# Altium schematic sheet 07_CAMERA_INTERFACE_2.SchDoc(07_CAMERA_INTERFACE_2)
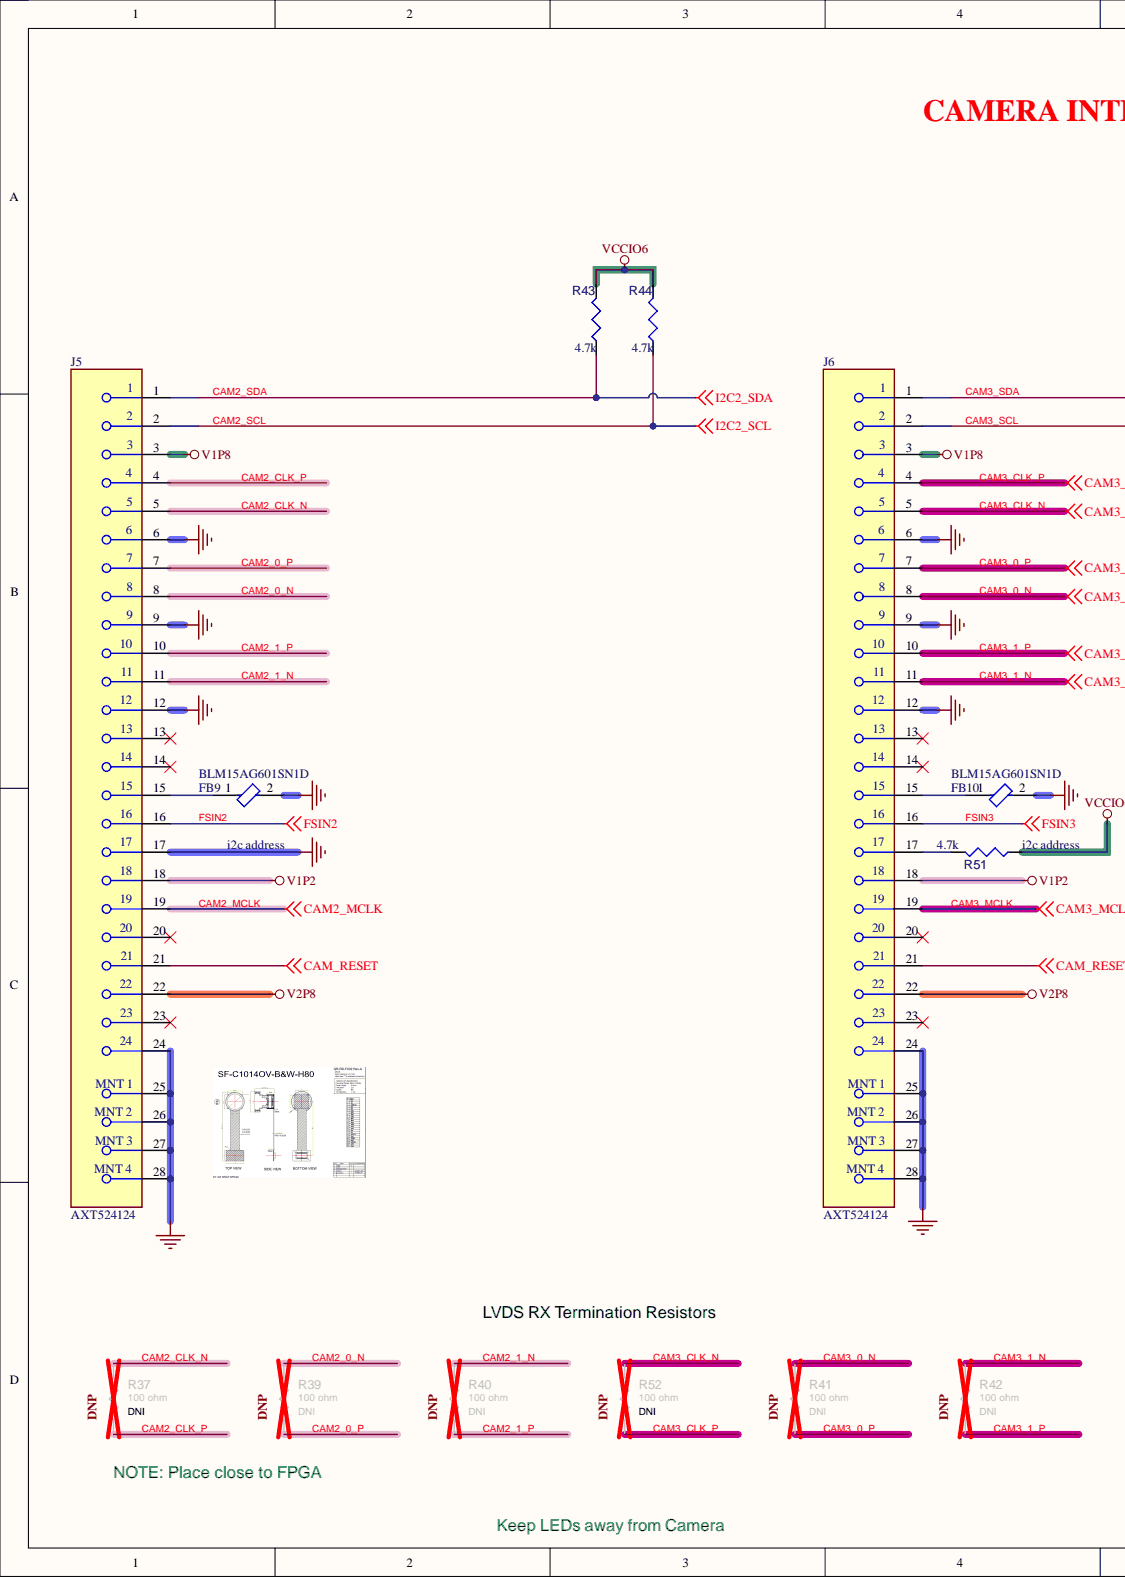
[diagram p0: 07_CAMERA_INTERFACE_2.SchDoc, left]
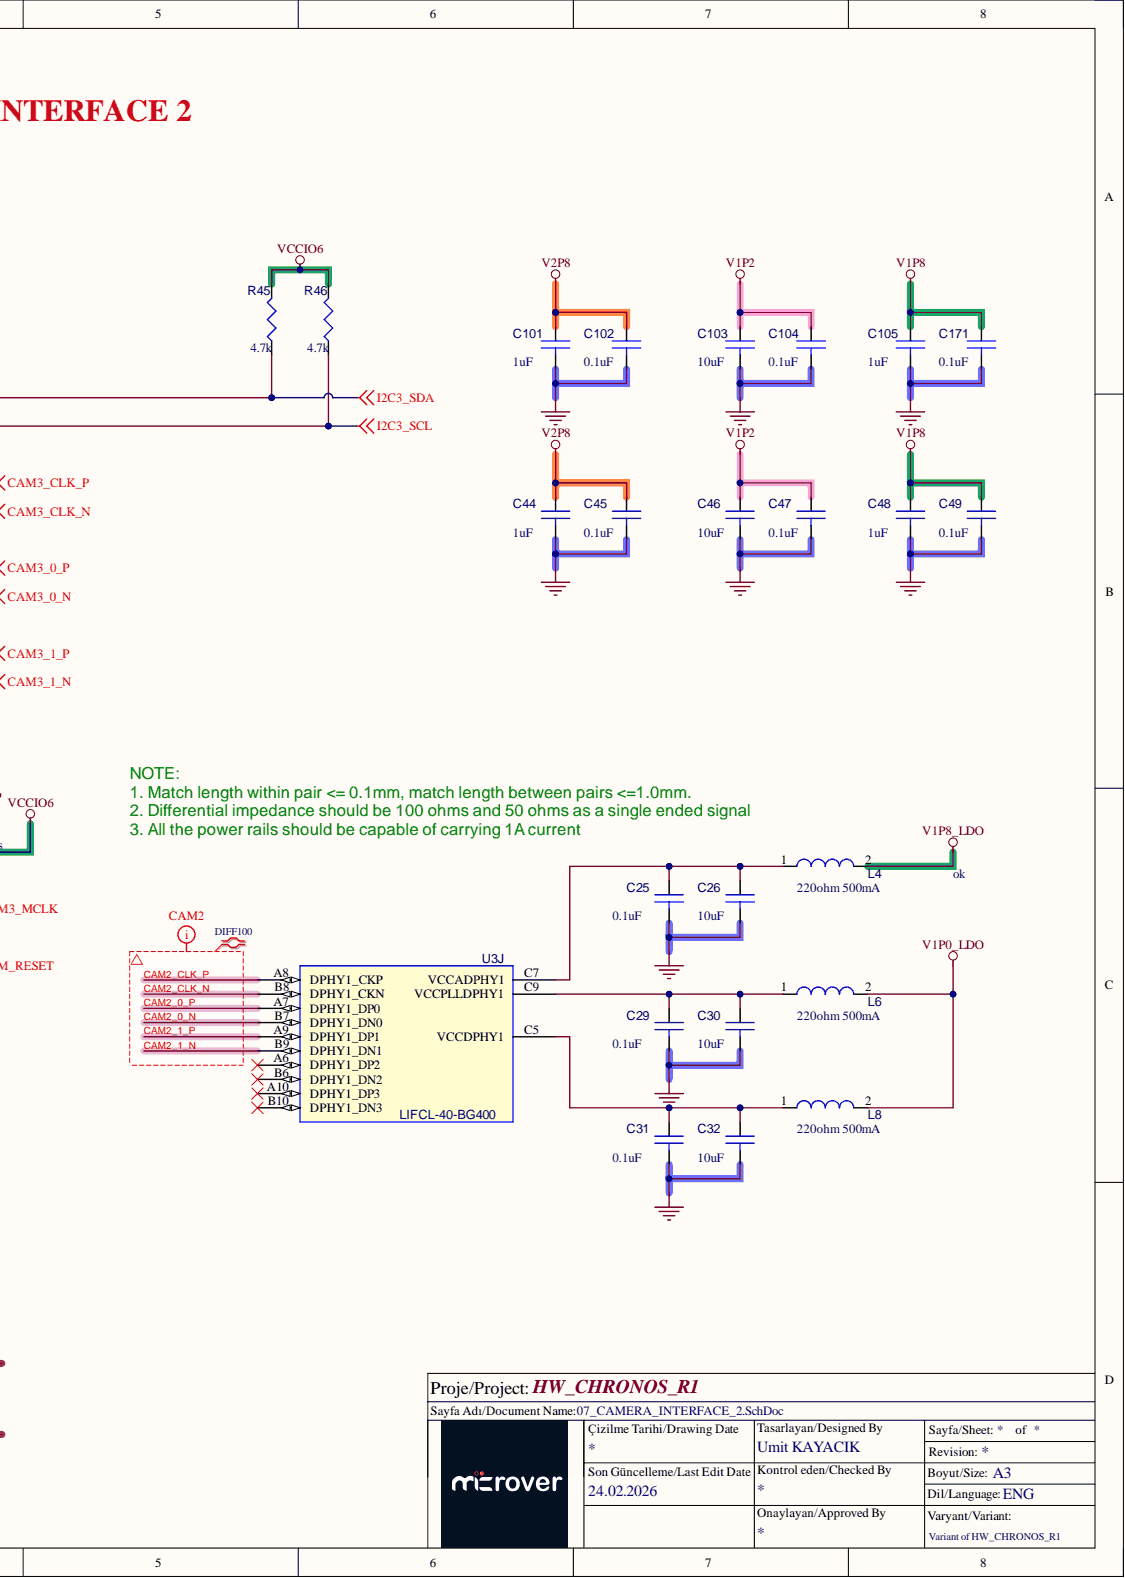
[diagram p1: 07_CAMERA_INTERFACE_2.SchDoc, right]

## Parts
C25  0.1uF  Part Reference: C25  [C0201]
C26  10uF  Part Reference: C26  [C0402]
C29  0.1uF  Part Reference: C29  [C0201]
C30  10uF  Part Reference: C30  [C0402]
C31  0.1uF  Part Reference: C31  [C0201]
C32  10uF  Part Reference: C32  [C0402]
C44  1uF  [C0603]
C45  0.1uF  [C0201]
C46  10uF  [C0603]
C47  0.1uF  [C0201]
C48  1uF  [C0603]
C49  0.1uF  [C0201]
C101  1uF  [C0603]
C102  0.1uF  [C0201]
C103  10uF  [C0603]
C104  0.1uF  [C0201]
C105  1uF  [C0603]
C171  0.1uF  [C0201]
FB9  BLM15AG601SN1D  [FP-BLM15-0_05-MFG]
FB10  BLM15AG601SN1D  [FP-BLM15-0_05-MFG]
J5  AXT524124  [FP-AXT524124-MFG]
J6  AXT524124  [FP-AXT524124-MFG]
L4  220ohm 500mA  Part Reference: L4  [FB0402]
L6  220ohm 500mA  Part Reference: L6  [FB0402]
L8  220ohm 500mA  Part Reference: L8  [FB0402]
R37  100 ohm  Assy: DNI  [R0201]
R39  100 ohm  Assy: DNI  [R0201]
R40  100 ohm  Assy: DNI  [R0201]
R41  100 ohm  Assy: DNI  [R0201]
R42  100 ohm  Assy: DNI  [R0201]
R43  4.7k  [R0402]
R44  4.7k  [R0402]
R45  4.7k  [R0402]
R46  4.7k  [R0402]
R51  4.7k  [R0402]
R52  100 ohm  Assy: DNI  [R0201]
U3  LIFCL-40-BG400  [LIFCL-40-BG400]  (part J)

## Nets
CAM_RESET: J5.21 J6.21
GND: C25.2 C26.2 C29.2 C30.2 C31.2 C32.2 C44.2 C45.2 C46.2 C47.2 C48.2 C49.2 C101.2 C102.2 C103.2 C104.2 C105.2 C171.2 FB9.2 FB10.2 J5.6 J5.9 J5.12 J5.17 J5.24 J5.25 J5.26 J5.27 J5.28 J6.6 J6.9 J6.12 J6.24 J6.25 J6.26 J6.27 J6.28
V1P0_LDO: L6.2 L8.2
V1P2: C46.1 C47.1 C103.1 C104.1 J5.18 J6.18
V1P8: C48.1 C49.1 C105.1 C171.1 J5.3 J6.3
V1P8_LDO: L4.2
V2P8: C44.1 C45.1 C101.1 C102.1 J5.22 J6.22
VCCIO6: R43.1 R44.1 R45.1 R46.1 R51.2
CAM2_0_N: J5.8 R39.2 U3.B7(DPHY1_DN0)
CAM2_0_P: J5.7 R39.1 U3.A7(DPHY1_DP0)
CAM2_1_N: J5.11 R40.2 U3.B9(DPHY1_DN1)
CAM2_1_P: J5.10 R40.1 U3.A9(DPHY1_DP1)
CAM2_CLK_N: J5.5 R37.2 U3.B8(DPHY1_CKN)
CAM2_CLK_P: J5.4 R37.1 U3.A8(DPHY1_CKP)
CAM2_MCLK: J5.19
CAM2_SCL: J5.2 R44.2
CAM2_SDA: J5.1 R43.2
CAM3_0_N: J6.8 R41.2
CAM3_0_P: J6.7 R41.1
CAM3_1_N: J6.11 R42.2
CAM3_1_P: J6.10 R42.1
CAM3_CLK_N: J6.5 R52.2
CAM3_CLK_P: J6.4 R52.1
CAM3_MCLK: J6.19
CAM3_SCL: J6.2 R46.2
CAM3_SDA: J6.1 R45.2
FSIN2: J5.16
FSIN3: J6.16
NetC25_1: C25.1 C26.1 L4.1 U3.C7(VCCADPHY1)
NetC29_1: C29.1 C30.1 L6.1 U3.C9(VCCPLLDPHY1)
NetC31_1: C31.1 C32.1 L8.1 U3.C5(VCCDPHY1)
NetFB9_1: FB9.1 J5.15
NetFB10_1: FB10.1 J6.15
NetJ6_17: J6.17 R51.1

Not connected: J5 13 14 20 23; J6 13 14 20 23; U3 A6(DPHY1_DP2) A10(DPHY1_DP3) B6(DPHY1_DN2) B10(DPHY1_DN3)

## Library data of the parts
C25 C29 C31 = 0u1_0402: CAP CER 0.1UF 25V 10% X5R 0201; Manufacturer: Murata; Manufacturer Part Number: GRM033R61E104KE14J; Class: Discrete; Assy: -; Component Kind: Standard; Digikey P/N: 399-3521-2-ND; PCB Footprint: C0201; Value: 0.1uF
C26 C30 C32 = 10uF_0603: CAP CER 10UF 10V X5R 0402; Manufacturer: Samsung; Manufacturer Part Number: CL05A106MP8NUB8; Class: Discrete; Assy: -; Component Kind: Standard; Digikey P/N: 587-2562-1-ND; PCB Footprint: C0402; Value: 10uF
C44 C101 = 10uF_0603: CAP CECAP CER 10UF 10V X5R 20% 0603; Manufacturer: Taiyo Yuden; Manufacturer Part Number: LMK107BJ106MALTD; Part Reference: C21; Class: Discrete; Assy: -; Component Kind: Standard; Digikey P/N: 587-2562-1-ND; PCB Footprint: C0603; Value: 1uF
C45 C102 = 0u1_0402: CAP CER 0.1UF 25V 10% X5R 0201; Manufacturer: Murata; Manufacturer Part Number: GRM033R61E104KE14J; Part Reference: C18; Class: Discrete; Assy: -; Component Kind: Standard; Digikey P/N: 399-3521-2-ND; PCB Footprint: C0201; Value: 0.1uF
C46 C103 = 10uF_0603: CAP CECAP CER 10UF 10V X5R 20% 0603; Manufacturer: Taiyo Yuden; Manufacturer Part Number: LMK107BJ106MALTD; Part Reference: C22; Class: Discrete; Assy: -; Component Kind: Standard; Digikey P/N: 587-2562-1-ND; PCB Footprint: C0603; Value: 10uF
C47 C104 = 0u1_0402: CAP CER 0.1UF 25V 10% X5R 0201; Manufacturer: Murata; Manufacturer Part Number: GRM033R61E104KE14J; Part Reference: C19; Class: Discrete; Assy: -; Component Kind: Standard; Digikey P/N: 399-3521-2-ND; PCB Footprint: C0201; Value: 0.1uF
C48 C105 = 10uF_0603: CAP CECAP CER 10UF 10V X5R 20% 0603; Manufacturer: Taiyo Yuden; Manufacturer Part Number: LMK107BJ106MALTD; Part Reference: C23; Class: Discrete; Assy: -; Component Kind: Standard; Digikey P/N: 587-2562-1-ND; PCB Footprint: C0603; Value: 1uF
C49 C171 = 0u1_0402: CAP CER 0.1UF 25V 10% X5R 0201; Manufacturer: Murata; Manufacturer Part Number: GRM033R61E104KE14J; Part Reference: C24; Class: Discrete; Assy: -; Component Kind: Standard; Digikey P/N: 399-3521-2-ND; PCB Footprint: C0201; Value: 0.1uF
FB9 FB10 = CMP-0686-00187-4: Chip Ferrite Bead, 0402, 600Ω @ 100MHz, 0.52Ω, 25%, 300mA; DC Resistance (DCR): 520mR; DC Resistance-Max: 600mR; Material: Steel; Number of Circuits: 1; Shielding: Unshielded; Impedance: 600R; Case Code (Metric): 1005; Case Code (Imperial): 0402; Case/Package: 0402; Component Kind: Standard; Core Material: Ferrite; Current Rating: 300mA; DC Current: 300mA; Depth: 0.55mm; Frequency: 100MHz; Height: 0.5mm; Lead Free: Lead Free; Length: 1mm; Library Name: Altium Content Vault; Max Current Rating: 300mA; Max DC Current: 300mA; Max Operating Temperature: 125°C; Military Standard: Not; Min Operating Temperature: -55°C; Number of Lines: 1; Number of Pins: 2; Package Quantity: 10000; Packaging: Tape and Reel; Radiation Hardening: No; REACH SVHC: No SVHC; RoHS Compliant: Yes; Series: BLM15AG_SN; Termination: SMD/SMT; Test Frequency: 100MHz; Tolerance: 25%; Width: 0.5mm
J5 J6 = AXT524124: AXT524124 24 Position 0.4 mm Pitch Dual Row Board to Board Socket Narrow Pitch Connector; NexarPartId: 7879795; Contact Resistance: 90mΩ; Current Rating: 300mA; Gender: Receptacle; Mated Stacking Heights: 1mm; Max Current Rating: 5A; Max Voltage Rating (AC): 60V; Max Voltage Rating (DC): 6.06kV; Number of Contacts: 24; Pitch: 400µm; Insulation Resistance: 1GΩ; Ambient Temperature Range High: 85°C; Component Kind: Standard; Connector Type: Socket; Contact Material: Gold, Nickel; Contact Plating: Gold; Depth: 3mm; Height: 1.17mm; Housing Material: Polymer; Lead Free: Lead Free; Length: 7.3mm; Max Operating Temperature: 85°C; Min Operating Temperature: -55°C; Mount: Surface Mount; Number of Positions: 24; Number of Rows: 2; Orientation: Straight; Packaging: Cut Tape (CT); Radiation Hardening: No; RoHS: Compliant; Termination: Solder; Voltage Rating: 60V; Library Name: Chronos.SchLib
L4 L6 L8 = FB0603: FERRITE BEAD 600 OHM 0402 1LN; Manufacturer: Murata; Manufacturer Part Number: BLM15AX601SN1D; Class: Discrete; Assy: -; Component Kind: Standard; Digikey P/N: 490-1014-1-ND; PCB Footprint: FB0402; Value: 220ohm 500mA
R37 R52 = RES_100K_1W16_0402_5P: RES SMD 100 OHM 1% 1/20W 0201; Manufacturer: Yageo; Manufacturer Part Number: RC0201FR-07100RL; Part Reference: R27; DIST: FLAT; MAX_TEMP: RTMAX; TC1: 0; Total Area(sq-mm): 0.5; Unit Price (USD): 0.02; Wattage: 1/16W; Component Kind: Standard; Length(mm): 1; MFG: Yageo; MFG P/N: RC0201FR-07100RL; PCB Footprint: R0201; TC2: 0; Value: 100 ohm; Width(mm): 0.5
R39 = RES_100K_1W16_0402_5P: RES SMD 100 OHM 1% 1/20W 0201; Manufacturer: Yageo; Manufacturer Part Number: RC0201FR-07100RL; Part Reference: R28; DIST: FLAT; MAX_TEMP: RTMAX; TC1: 0; Total Area(sq-mm): 0.5; Unit Price (USD): 0.02; Wattage: 1/16W; Component Kind: Standard; Length(mm): 1; MFG: Yageo; MFG P/N: RC0201FR-07100RL; PCB Footprint: R0201; TC2: 0; Value: 100 ohm; Width(mm): 0.5
R40 = RES_100K_1W16_0402_5P: RES SMD 100 OHM 1% 1/20W 0201; Manufacturer: Yageo; Manufacturer Part Number: RC0201FR-07100RL; Part Reference: R29; DIST: FLAT; MAX_TEMP: RTMAX; TC1: 0; Total Area(sq-mm): 0.5; Unit Price (USD): 0.02; Wattage: 1/16W; Component Kind: Standard; Length(mm): 1; MFG: Yageo; MFG P/N: RC0201FR-07100RL; PCB Footprint: R0201; TC2: 0; Value: 100 ohm; Width(mm): 0.5
R41 = RES_100K_1W16_0402_5P: RES SMD 100 OHM 1% 1/20W 0201; Manufacturer: Yageo; Manufacturer Part Number: RC0201FR-07100RL; Part Reference: R30; DIST: FLAT; MAX_TEMP: RTMAX; TC1: 0; Total Area(sq-mm): 0.5; Unit Price (USD): 0.02; Wattage: 1/16W; Component Kind: Standard; Length(mm): 1; MFG: Yageo; MFG P/N: RC0201FR-07100RL; PCB Footprint: R0201; TC2: 0; Value: 100 ohm; Width(mm): 0.5
R42 = RES_100K_1W16_0402_5P: RES SMD 100 OHM 1% 1/20W 0201; Manufacturer: Yageo; Manufacturer Part Number: RC0201FR-07100RL; Part Reference: R31; DIST: FLAT; MAX_TEMP: RTMAX; TC1: 0; Total Area(sq-mm): 0.5; Unit Price (USD): 0.02; Wattage: 1/16W; Component Kind: Standard; Length(mm): 1; MFG: Yageo; MFG P/N: RC0201FR-07100RL; PCB Footprint: R0201; TC2: 0; Value: 100 ohm; Width(mm): 0.5
R43 R45 = 5k1_0402: RES SMD 2.2K OHM 1% 1/10W 0402; Manufacturer: Panasonic; Manufacturer Part Number: ERJ-2RKF2201X; Part Reference: R25; Class: Discrete; Assy: -; BOM NOTE: -; Component Kind: Standard; Digikey P/N: 311-5.10KLRTR-ND; PCB Footprint: R0402; Value: 4.7k
R44 R46 R51 = 5k1_0402: RES SMD 2.2K OHM 1% 1/10W 0402; Manufacturer: Panasonic; Manufacturer Part Number: ERJ-2RKF2201X; Part Reference: R26; Class: Discrete; Assy: -; BOM NOTE: -; Component Kind: Standard; Digikey P/N: 311-5.10KLRTR-ND; PCB Footprint: R0402; Value: 4.7k
U3 = LIFCL-40-BG400: Part Reference: U3; Graphic: LIFCL-40-BG400A.Normal; Location X-Coordinate: 0; Name: I17266603; Power Pins Visible: False; Primitive: DEFAULT; Source Library: C:\USERS\SLIU1\DOCUMENTS\PCB_DESIGN\JEDI_EVAL_BOARD\SCHEMATIC\LIFCL40.OLB; SPLIT_INST: TRUE; SWAP_INFO: (S1+S2+S3+S4+S5+S6+S7+S8+S9+S10+S11+S12+S13+S14+S15); Implementation Type: <none>; Assy: -; Color: Default; Component Kind: Standard; Location Y-Coordinate: 0; PCB Footprint: LIFCL-40-BG400; Source Package: LIFCL-40-BG400; Source Part: LIFCL-40-BG400A.Normal; Value: LIFCL-40-BG400
